# Altium schematic sheet ublox.SchDoc
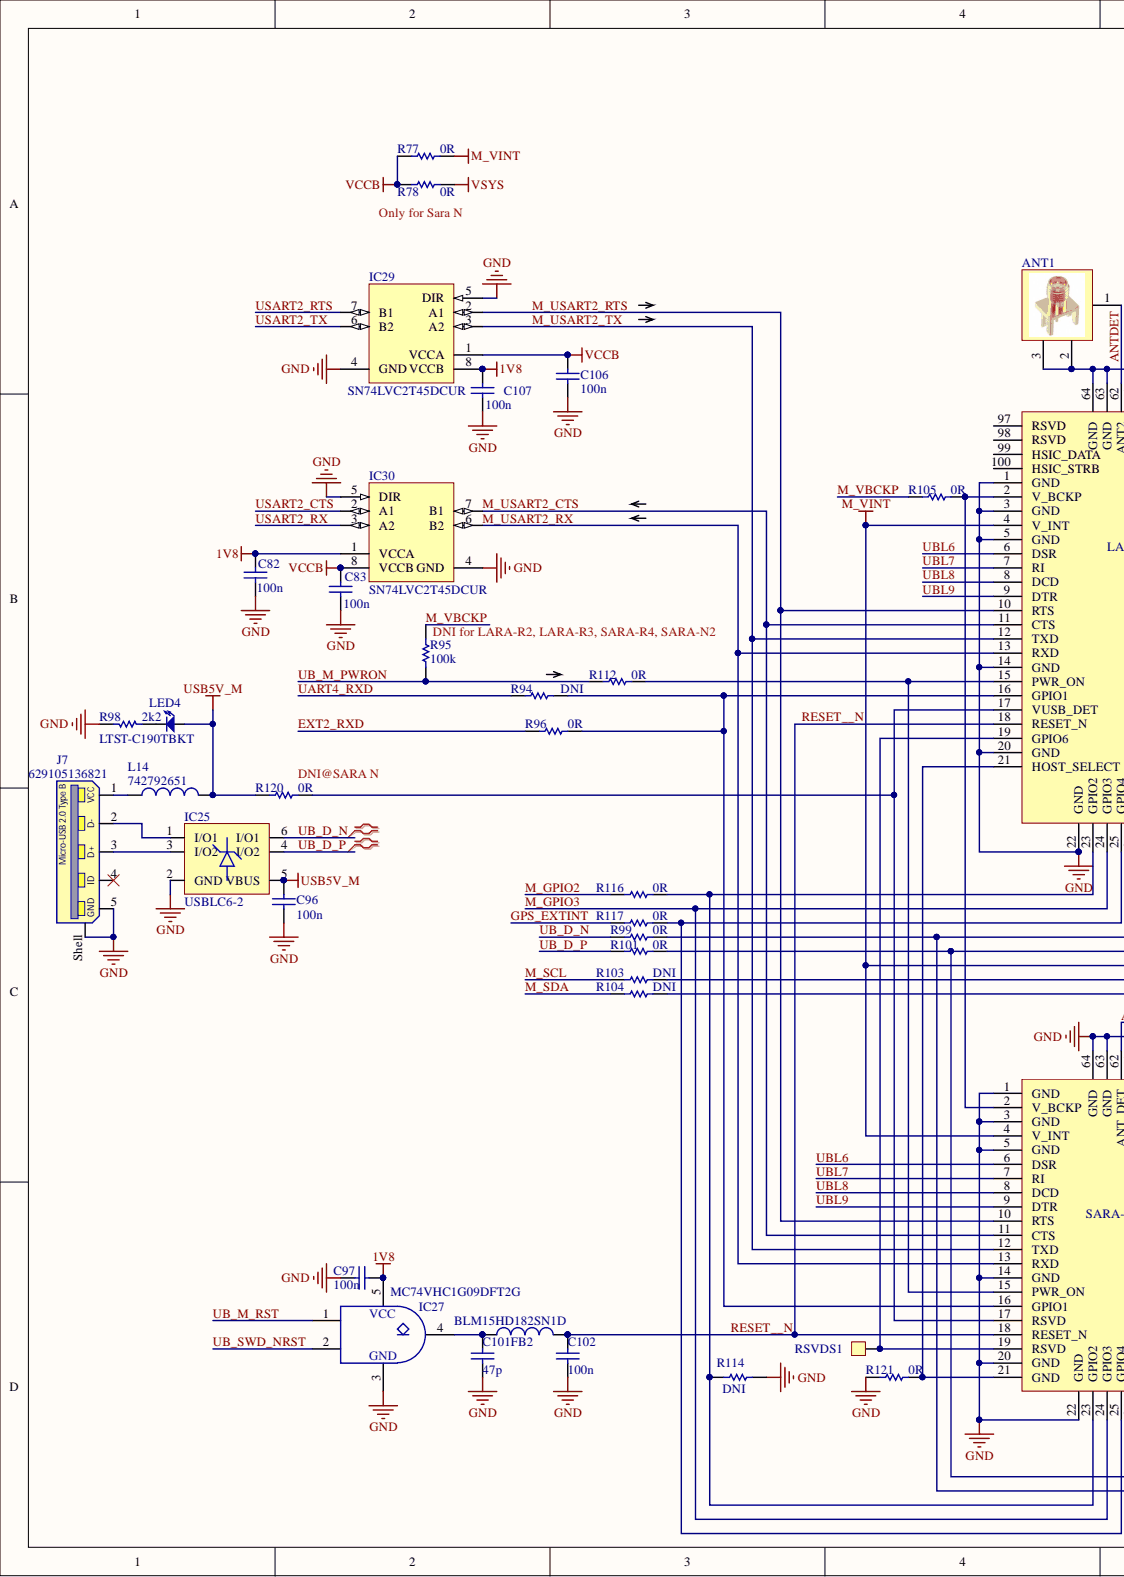
[diagram p0: ublox.SchDoc, left]
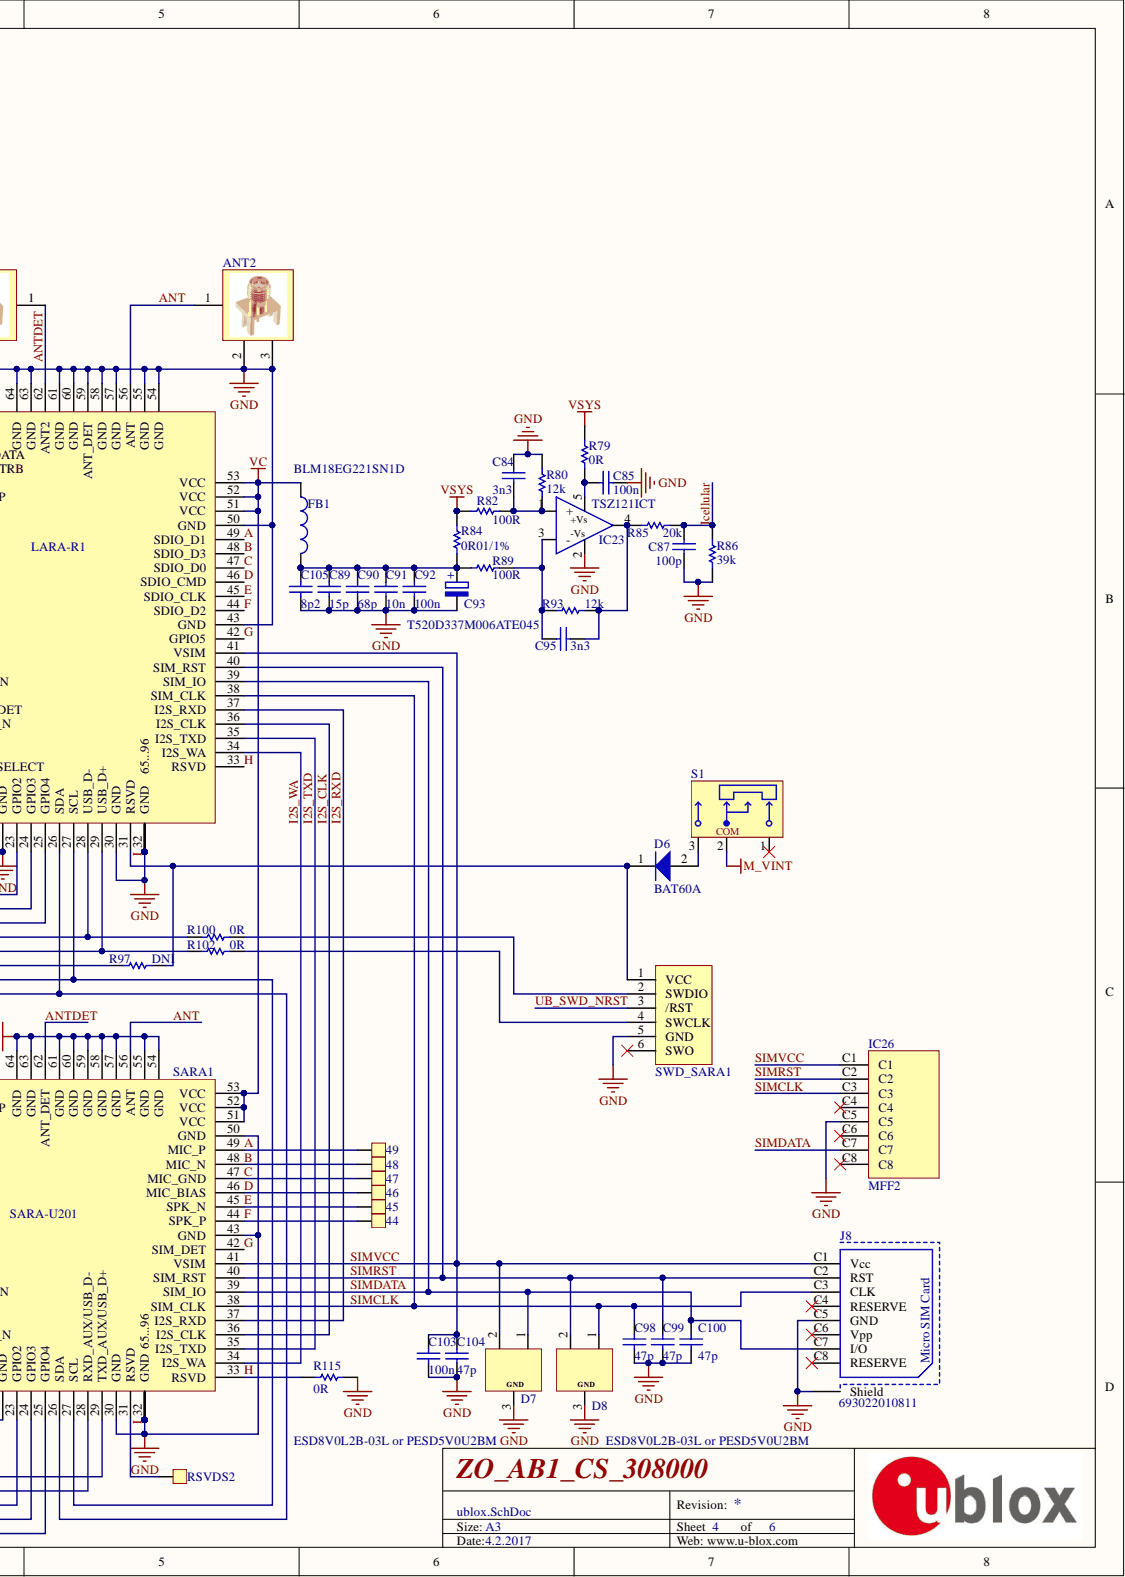
[diagram p1: ublox.SchDoc, right]

## Parts
44  Header 1  [HEADER1 (1x1) SMT]  (value not drawn)  (no BOM)
45  Header 1  [HEADER1 (1x1) SMT]  (value not drawn)  (no BOM)
46  Header 1  [HEADER1 (1x1) SMT]  (value not drawn)  (no BOM)
47  Header 1  [HEADER1 (1x1) SMT]  (value not drawn)  (no BOM)
48  Header 1  [HEADER1 (1x1) SMT]  (value not drawn)  (no BOM)
49  Header 1  [HEADER1 (1x1) SMT]  (value not drawn)  (no BOM)
ANT1  Molex 0732511150  [Molex 0732511150]  (value not drawn)
ANT2  Molex 0732511150  [Molex 0732511150]  (value not drawn)
C82  100n  [C0402]
C83  100n  [C0402]
C84  3n3  [C0402]
C85  100n  [C0402]
C87  100p  [C0402]
C89  15p  [C0402]
C90  68p  [C0402]
C91  10n  [C0402]
C92  100n  [C0402]
C93  T520D337M006ATE045  [CTANT-D]
C95  3n3  [C0402]
C96  100n  [C0402]
C97  100n  [C0402]
C98  47p  [C0402]
C99  47p  [C0402]
C100  47p  [C0402]
C101  47p  [C0402]
C102  100n  [C0402]
C103  100n  [C0402]
C104  47p  [C0402]
C105  8p2  [C0402]
C106  100n  [C0402]
C107  100n  [C0402]
D6  BAT60A  [INF-SOT323_L]
D7  ESD8V0L2B-03L or PESD5V0U2BM  [SOT883 (SC101)]
D8  ESD8V0L2B-03L or PESD5V0U2BM  [SOT883 (SC101)]
FB1  BLM18EG221SN1D  [L0603]
FB2  BLM15HD182SN1D  [L0402]
IC23  TSZ121ICT  [SC70_(DCK)]
IC25  USBLC6-2  [SOT23-6L]
IC26  MFF2  [MFF2-L]
IC27  MC74VHC1G09DFT2G  [SC70_(DCK)]
IC29  SN74LVC2T45DCUR  [DCU0008A_L]
IC30  SN74LVC2T45DCUR  [DCU0008A_L]
J7  629105136821  [629105136821]
J8  693022010811  [693022010811]
L14  742792651  [L0603]
LARA-R1  LARA-R2  [LARA-R2]  (value not drawn)
LED4  LTST-C190TBKT  [LED0603 - BLUE]
R77  0R  [R0402]
R78  0R  [R0402]
R79  0R  [R0402]
R80  12k  [R0402]
R82  100R  [R0402]
R84  0R01/1%  [R0603]
R85  20k  [R0402]
R86  39k  [R0402]
R89  100R  [R0402]
R93  12k  [R0402]
R94  DNI  [R0402]
R95  100k  [R0402]
R96  0R  [R0402]
R97  DNI  [R0402]
R98  2k2  [R0402]
R99  0R  [R0402]
R100  0R  [R0402]
R101  0R  [R0402]
R102  0R  [R0402]
R103  DNI  [R0402]
R104  DNI  [R0402]
R105  0R  [R0402]
R112  0R  [R0402]
R114  DNI  [R0402]
R115  0R  [R0402]
R116  0R  [R0402]
R117  0R  [R0402]
R120  0R  [R0402]
R121  0R  [R0402]
RSVDS1  Header 1  [HEADER1 (1x1) SMT]  (value not drawn)  (no BOM)
RSVDS2  Header 1  [HEADER1 (1x1) SMT]  (value not drawn)  (no BOM)
S1  450404015514  [450404015514]  (value not drawn)
SARA1  SARA-U201  [SARA-G3]
SWD_SARA1  TC2030-CTL-NL  [TC2030-MCP-NL]  (value not drawn)  (no BOM)

## Nets
1V8: C82.2 C97.1 C107.1 IC27.5 IC29.8(VCCB) IC30.1(VCCA)
GND: ANT1.2 ANT1.3 ANT2.2 ANT2.3 C82.1 C83.2 C84.2 C85.1 C87.1 C89.1 C90.1 C91.1 C92.1 C93.- C96.1 C97.2 C98.1 C99.1 C100.1 C101.1 C102.1 C103.1 C104.1 C105.1 C106.1 C107.2 D7.3 D8.3 IC23.2 IC25.2(GND) IC26.C5 IC27.3 IC29.4(GND) IC29.5(DIR) IC30.4(GND) IC30.5(DIR) J7.5 J7.Shell J8.C5(GND) J8.Shield LARA-R1.1(GND) LARA-R1.3(GND) LARA-R1.5(GND) LARA-R1.14(GND) LARA-R1.20(GND) LARA-R1.22(GND) LARA-R1.30(GND) LARA-R1.32(GND) LARA-R1.43(GND) LARA-R1.50(GND) LARA-R1.54(GND) LARA-R1.55(GND) LARA-R1.57(GND) LARA-R1.58(GND) LARA-R1.59(ANT_DET) LARA-R1.60(GND) LARA-R1.61(GND) LARA-R1.63(GND) LARA-R1.64(GND) LARA-R1.65 LARA-R1.66 LARA-R1.67 LARA-R1.68 LARA-R1.69 LARA-R1.70 LARA-R1.71 LARA-R1.72 LARA-R1.73 LARA-R1.74 LARA-R1.75 LARA-R1.76 LARA-R1.77 LARA-R1.78 LARA-R1.79 LARA-R1.80 LARA-R1.81 LARA-R1.82 LARA-R1.83 LARA-R1.84 LARA-R1.85 LARA-R1.86 LARA-R1.87 LARA-R1.88 LARA-R1.89 LARA-R1.90 LARA-R1.91 LARA-R1.92 LARA-R1.93 LARA-R1.94 LARA-R1.95 LARA-R1.96 R80.2 R86.1 R98.2 R114.1 R115.2 R121.2 SARA1.1(GND) SARA1.3(GND) SARA1.5(GND) SARA1.14(GND) SARA1.20(GND) SARA1.22(GND) SARA1.30(GND) SARA1.32(GND) SARA1.43(GND) SARA1.50(GND) SARA1.54(GND) SARA1.55(GND) SARA1.57(GND) SARA1.58(GND) SARA1.59(GND) SARA1.60(GND) SARA1.61(GND) SARA1.63(GND) SARA1.64(GND) SARA1.65 SARA1.66 SARA1.67 SARA1.68 SARA1.69 SARA1.70 SARA1.71 SARA1.72 SARA1.73 SARA1.74 SARA1.75 SARA1.76 SARA1.77 SARA1.78 SARA1.79 SARA1.80 SARA1.81 SARA1.82 SARA1.83 SARA1.84 SARA1.85 SARA1.86 SARA1.87 SARA1.88 SARA1.89 SARA1.90 SARA1.91 SARA1.92 SARA1.93 SARA1.94 SARA1.95 SARA1.96 SWD_SARA1.5(GND)
M_VINT: LARA-R1.4(V_INT) R77.1 R97.2 S1.2 SARA1.4(V_INT)
USB5V_M: C96.2 IC25.5(VBUS) L14.2 LED4.A R120.2
VC: FB1.1 LARA-R1.51(VCC) LARA-R1.52(VCC) LARA-R1.53(VCC) SARA1.51(VCC) SARA1.52(VCC) SARA1.53(VCC)
VCCB: C83.1 C106.2 IC29.1(VCCA) IC30.8(VCCB) R77.2 R78.2
VSYS: R78.1 R79.2 R82.1 R84.2
A: 49.1 LARA-R1.49(SDIO_D1) SARA1.49(MIC_P)
ANT: ANT2.1 LARA-R1.56(ANT) SARA1.56(ANT)
ANTDET: ANT1.1 LARA-R1.62(ANT2) SARA1.62(ANT_DET)
B: 48.1 LARA-R1.48(SDIO_D3) SARA1.48(MIC_N)
C: 47.1 LARA-R1.47(SDIO_D0) SARA1.47(MIC_GND)
D: 46.1 LARA-R1.46(SDIO_CMD) SARA1.46(MIC_BIAS)
E: 45.1 LARA-R1.45(SDIO_CLK) SARA1.45(SPK_N)
EXT2_RXD: R96.2
F: 44.1 LARA-R1.44(SDIO_D2) SARA1.44(SPK_P)
G: LARA-R1.42(GPIO5) SARA1.42(SIM_DET)
GPS_EXTINT: R117.1
H: LARA-R1.33(RSVD) R115.1 SARA1.33(RSVD)
I: D6.1 LARA-R1.31(RSVD) R97.1 RSVDS2.1 SARA1.31(RSVD) SWD_SARA1.1(VCC)
I2S_CLK: LARA-R1.36(I2S_CLK) SARA1.36(I2S_CLK)
I2S_RXD: LARA-R1.37(I2S_RXD) SARA1.37(I2S_RXD)
I2S_TXD: LARA-R1.35(I2S_TXD) SARA1.35(I2S_TXD)
I2S_WA: LARA-R1.34(I2S_WA) SARA1.34(I2S_WA)
ICELLULAR: C87.2 R85.1 R86.2
M_GPIO2: R116.1
M_GPIO3: LARA-R1.24(GPIO3) SARA1.24(GPIO3)
M_SCL: R103.2
M_SDA: R104.2
M_USART2_CTS: IC30.7(B1) LARA-R1.11(CTS) SARA1.11(CTS)
M_USART2_RTS: IC29.2(A1) LARA-R1.10(RTS) SARA1.10(RTS)
M_USART2_RX: IC30.6(B2) LARA-R1.13(RXD) SARA1.13(RXD)
M_USART2_TX: IC29.3(A2) LARA-R1.12(TXD) SARA1.12(TXD)
M_VBCKP: R95.2 R105.2
RESET__N: C102.2 FB2.2 LARA-R1.18(RESET_N) SARA1.18(RESET_N)
SIMCLK: C98.2 D8.1 IC26.C3 J8.C3(CLK) LARA-R1.38(SIM_CLK) SARA1.38(SIM_CLK)
SIMDATA: C100.2 D7.1 IC26.C7 J8.C7(I/O) LARA-R1.39(SIM_IO) SARA1.39(SIM_IO)
SIMRST: C99.2 D8.2 IC26.C2 J8.C2(RST) LARA-R1.40(SIM_RST) SARA1.40(SIM_RST)
SIMVCC: C103.2 C104.2 D7.2 IC26.C1 J8.C1(Vcc) LARA-R1.41(VSIM) SARA1.41(VSIM)
UART4_RXD: R94.1
UB_D_N: IC25.6(I/O1) R99.2
UB_D_P: IC25.4(I/O2) R101.2
UB_M_PWRON: R95.1 R112.2
UB_M_RST: IC27.1
UB_SWD_NRST: IC27.2 SWD_SARA1.3(/RST)
UBL6: LARA-R1.6(DSR) SARA1.6(DSR)
UBL7: LARA-R1.7(RI) SARA1.7(RI)
UBL8: LARA-R1.8(DCD) SARA1.8(DCD)
UBL9: LARA-R1.9(DTR) SARA1.9(DTR)
USART2_CTS: IC30.2(A1)
USART2_RTS: IC29.7(B1)
USART2_RX: IC30.3(A2)
USART2_TX: IC29.6(B2)
NetC84_1: C84.1 IC23.1(+) R80.1 R82.2
NetC85_2: C85.2 IC23.5 R79.1
NetC89_2: C89.2 C90.2 C91.2 C92.2 C93.+ C105.2 FB1.2 R84.1 R89.1
NetC95_1: C95.1 IC23.4 R85.2 R93.1
NetC95_2: C95.2 IC23.3(-) R89.2 R93.2
NetC101_2: C101.2 FB2.1 IC27.4
NetD6_2: D6.2 S1.3
NetIC25_1: IC25.1(I/O1) J7.2(D-)
NetIC25_3: IC25.3(I/O2) J7.3(D+)
NetJ7_1: J7.1 L14.1
NetLARA-R1_2: LARA-R1.2(V_BCKP) R105.1 SARA1.2(V_BCKP)
NetLARA-R1_15: LARA-R1.15(PWR_ON) R112.1 SARA1.15(PWR_ON)
NetLARA-R1_16: LARA-R1.16(GPIO1) R94.2 R96.1 SARA1.16(GPIO1)
NetLARA-R1_17: LARA-R1.17(VUSB_DET) R120.1 SARA1.17(RSVD)
NetLARA-R1_19: LARA-R1.19(GPIO6) RSVDS1.1 SARA1.19(RSVD)
NetLARA-R1_21: LARA-R1.21(HOST_SELECT) R121.1 SARA1.21(GND)
NetLARA-R1_23: LARA-R1.23(GPIO2) R114.2 R116.2 SARA1.23(GPIO2)
NetLARA-R1_25: LARA-R1.25(GPIO4) R117.2 SARA1.25(GPIO4)
NetLARA-R1_26: LARA-R1.26(SDA) R104.1 SARA1.26(SDA)
NetLARA-R1_27: LARA-R1.27(SCL) R103.1 SARA1.27(SCL)
NetLARA-R1_28: LARA-R1.28(USB_D-) R99.1 R100.2 SARA1.28(RXD_AUX/USB_D-)
NetLARA-R1_29: LARA-R1.29(USB_D+) R101.1 R102.2 SARA1.29(TXD_AUX/USB_D+)
NetLED4_K: LED4.K R98.1
NetR100_1: R100.1 SWD_SARA1.2(SWDIO)
NetR102_1: R102.1 SWD_SARA1.4(SWCLK)

Not connected: IC26 C4 C6 C8; J7 4(ID); J8 C4(RESERVE) C6(Vpp) C8(RESERVE); LARA-R1 97(RSVD) 98(RSVD) 99(HSIC_DATA) 100(HSIC_STRB); S1 1; SWD_SARA1 6(SWO)

## Library data of the parts
44-49 RSVDS1 RSVDS2 = Header 1: Header 1 pin, 2,54mm raster; Library Name: BorisHeaders.SchLib
ANT1 ANT2 = Molex 0732511150: 50 Ohms, SMA Jack Receptacle, Edge Mount; Component Kind: Standard; Library Name: BorisCon.SchLib
C82-C85 C87 C89-C92 C95-C107 = Capacitor SMD: SMD chip capacitor; Value: Xn; Component Kind: Standard; LatestRevisionDate: 26-Dec-2008; Published: 26-Dec-2008; Signal Integrity: Cap; Simulation: CAP; Library Name: BorisPassive.SchLib; Publisher: www.poscope.com
C93 = Cap Tantal: Tantal Capacitor SMD; Value: Xu/XV; Component Kind: Standard; Library Name: BorisPassive.SchLib
D6 = BAT60A: Silicon Schottky Diode, -55 to 85 degC, SOD323, Reel, Green; Manufacturer: Infineon; Configuration: Single; CT (max): 35 pF; Green: TRUE; IF (max): 3,000 mA; VF (max): 370 mV; VR (max): 10 V; Component Kind: Standard; ComponentLink1Description: Manufacturer URL; ComponentLink1URL: http://www.infineon.com/; ComponentLink2Description: Datasheet; ComponentLink2URL: http://www.infineon.com/dgdl/bat60aseries.pdf?folderId=db3a304313d846880113def5812204a1&fileId=db3a304313d846880113def70c9304a9; ComponentLink3Description: Package Specification; ComponentLink3URL: http://www.infineon.com/dgdl/SOT323-Package_Overview.pdf?folderId=db3a30431936bc4b0119533e1dcb3c92&fileId=db3a30431936bc4b011953495f283cb1; Library Name: Infineon_Transistor_&_Diode.IntLib; Mounting Technology: Surface Mount; PackageDescription: 3-Pin Small Outline Transistor Package, 1.25 x 2 mm Body, 0.65 mm Pitch; PackageReference: SOT323; Packing: Reel; PartNumber: BAT60A; Supplier 1: Mouser; Supplier Part Number 1: N/A
D7 D8 = PESD5V0U2BM: Ultra low capacitance bidirectional double ESD  protection array; Component Kind: Standard; datasheet: http://www.nxp.com/documents/data_sheet/PESD5V0U2BM.pdf; link: http://www.nxp.com/products/esd_emi_and_signal_conditioning/general_esd_protection_devices/low_capacitance_esd_protection_devices/PESD5V0U2BM.html; Library Name: BorisSemi.SchLib
FB1 FB2 L14 = Inductor SMD: Value: XuH; Component Kind: Standard; LatestRevisionDate: 21 March 2009; Published: 21 March 2009; Library Name: BorisPassive.SchLib; Publisher: www.poscope.com
IC23 = TSZ121ICT: Very high accuracy (5 µV) zero drift micropower 5 V operational   amplifiers; Component Kind: Standard; Library Name: BorisIC1.SchLib
IC25 = USBLC6-2: Very low capacitance ESD protection; Component Kind: Standard; Library Name: BorisIC1.SchLib
IC26 = MFF2: eSIM; Component Kind: Standard; Library Name: MFF.SchLib
IC27 = MC74VHC1G09DFT2G: 2-Input AND Gate with  Open Drain Output; Component Kind: Standard; Library Name: BorisIC1.SchLib
IC29 IC30 = SN74LVC2T45DCUR: Dual-Bit Dual Supply Transceiver with Configurable Voltage Translation and 3-State Outputs, DCU0008A, LARGE T&R; Manufacturer: Texas Instruments; 3-State Output: Yes; Bits(#): 2; F @ Nom Voltage(Max)(Mhz): 150; ICC @ Nom Voltage(Max)(mA): 0.004; Input Type: CMOS/TTL; Logic: True; Output Drive (IOL/IOH)(Max)(mA): 32/-32; Output Type: CMOS; Rating: Military; Schmitt Trigger: No; Sub-Family: Dual Supply Translator; Technology Family: LVC; tpd @ Nom Voltage(Max)(ns): 17.7,10.3,8.3,7.2; VCC(Max)(V): 5.5; VCC(Min)(V): 1.65; Voltage(Nom)(V): 1.8,2.5,3.3,5; Component Kind: Standard; ComponentLink1Description: Manufacturer URL; ComponentLink1URL: http://www.ti.com/; ComponentLink2Description: Datasheet; ComponentLink2URL: http://www.ti.com/lit/ds/symlink/sn74lvc2t45.pdf; DatasheetVersion: SCES516J; Generic Part Number: SN74LVC2T45; Library Name: TI_Logic_Little_Logic.IntLib; Operating Temperature Range(C): -40 to 85; PackageReference: DCU0008A; PartNumber: SN74LVC2T45DCUR; Supplier 1: Mouser; Supplier 2: Digi-Key; Supplier 3: Digi-Key; Supplier 4: Digi-Key; Supplier Part Number 1: 595-SN74LVC2T45DCUR; Supplier Part Number 2: 296-17014-2-ND; Supplier Part Number 3: 296-17014-1-ND; Supplier Part Number 4: 296-17014-6-ND
J7 = 629105136821: WR-COM Micro USB 2.0 SMT Type B Horizontal 5 Contacts; Component Kind: Standard; ComponentLink1Description: Manufacturer; ComponentLink1URL: http://www.we-online.de; ComponentLink2Description: Datasheet; ComponentLink2URL: http://katalog.we-online.de/em/datasheet/629105136821.pdf; Library Name: ALTIUM-WR-COM-rev16d.IntLib; PartNumber: 629105136821; Published: June 2016; Publisher: Wurth Elektronik
J8 = 693022010811: WR-CRD Micro SIM Card Connector Hinge Type; Component Kind: Standard; ComponentLink1Description: Manufacturer; ComponentLink1URL: http://www.we-online.de; ComponentLink2Description: Datasheet; ComponentLink2URL: http://katalog.we-online.de/em/datasheet/693022010811.pdf; Library Name: ALTIUM-WR-CRD-rev16c.IntLib; PartNumber: 693022010811; Published: June 2016; Publisher: Wurth Elektronik
LARA-R1 = LARA-R2: ublox LTE Cat 1 / EGPRS modules; Designed by: Boris Zalokar; Component Kind: Standard; Library Name: uBlox.SchLib; link: https://www.u-blox.com/en/product/lara-r2-series
LED4 = LEDmini: Component Kind: Standard; Description: LEDmini; Library Name: BorisSemi.SchLib
R77-R80 R82 R84-R86 R89 R93-R105 R112 R114-R117 R120 R121 = Resistor SMD: SMD chip resistor; Value: Xk; Component Kind: Standard; LatestRevisionDate: 26-Dec-2008; Published: 26-Dec-2008; Signal Integrity: Res Semi; Simulation: RES; Library Name: BorisPassive.SchLib; Publisher: www.poscope.com
S1 = 450404015514: WS-SLSU 6.7x2.7 mm SMD Right Angled, boss design; Component Kind: Standard; ComponentLink1Description: Manufacturer; ComponentLink1URL: http://www.we-online.de; ComponentLink2Description: Datasheet; ComponentLink2URL: http://katalog.we-online.de/em/datasheet/450404015514.pdf; Library Name: ALTIUM-WS-SLSU-rev16a.IntLib; PartNumber: 450404015514; PublishedDate: Nov. 2016; Publisher: Wurth Elektronik
SARA1 = SARA-G3: ublox Versatile 2.5G GSM/GPRS modules; Component Kind: Standard; Library Name: uBlox.SchLib
SWD_SARA1 = CortexSWD: Tag Connect programming adapter footprint; Library Name: BorisConProg.SchLib
